# Altium schematic sheet FLASH.SchDoc(FLASH)
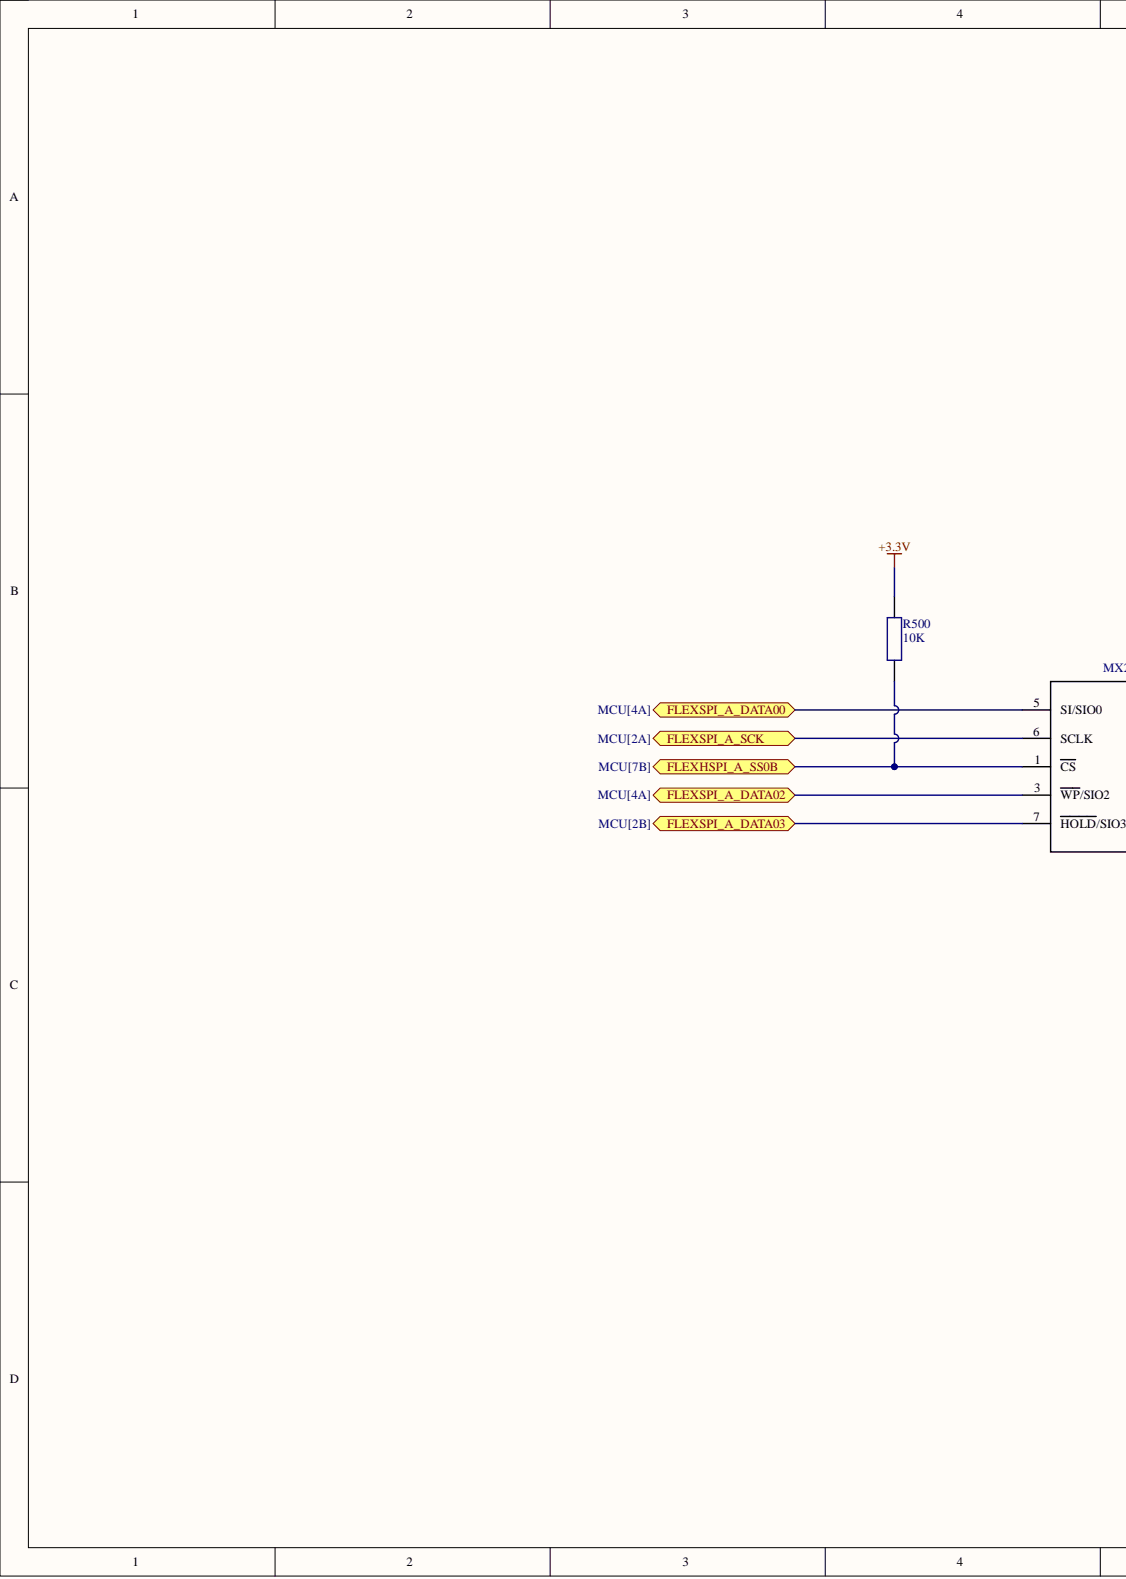
[diagram p0: FLASH.SchDoc, left]
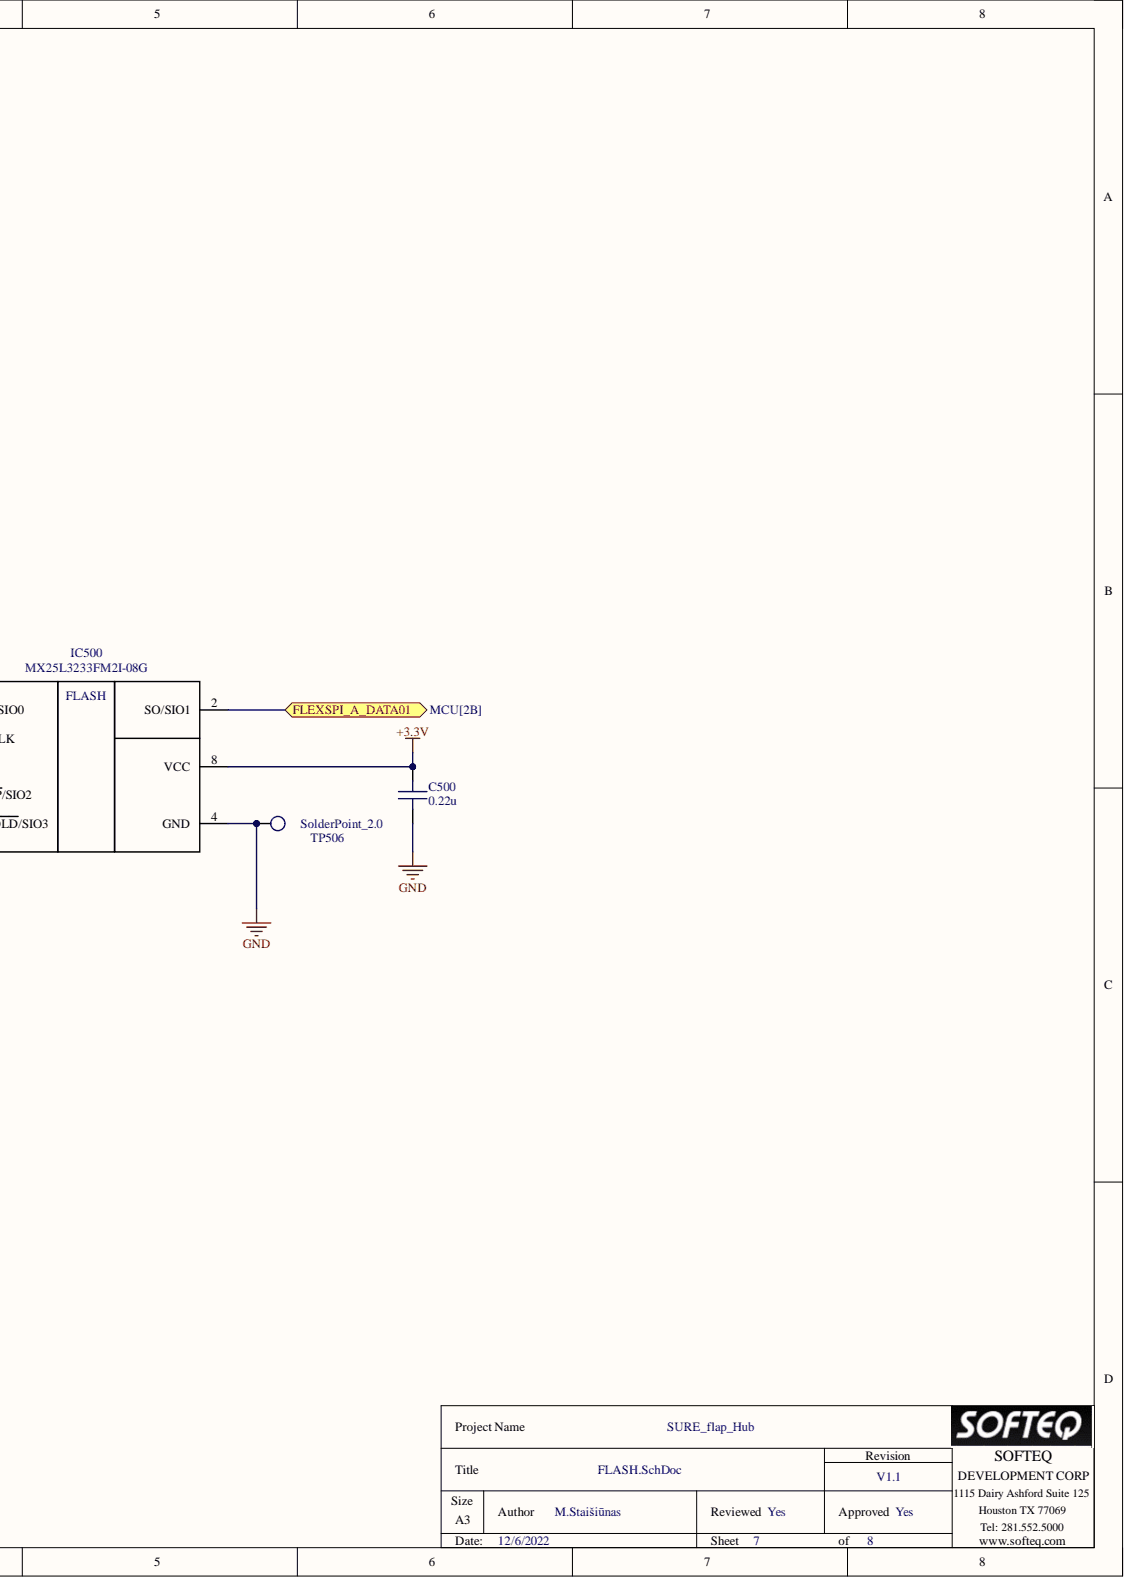
[diagram p1: FLASH.SchDoc, right]

## Parts
C500  value 0.22u  [C_0603]  (hidden comment: CC0603KRX7R9BB224)
IC500  MX25L3233FM2I-08G  [SOIC-8[4-4]_0.65_1.27_5.25X5.3X2.15]
R500  value 10K  [R_0402]  (hidden comment: RC0402FR-0710KL)
TP506  SolderPoint_2.0  [SSP_2.0]  (no BOM)

## Ports
FLEXHSPI_A_SS0B, FLEXSPI_A_DATA00, FLEXSPI_A_DATA01, FLEXSPI_A_DATA02, FLEXSPI_A_DATA03, FLEXSPI_A_SCK

## Nets
+3.3V: C500.2 IC500.8(VCC) R500.2
GND: C500.1 IC500.4(GND) TP506.1
FLEXHSPI_A_SS0B: IC500.1(CS) R500.1 ; port FLEXHSPI_A_SS0B
FLEXSPI_A_DATA00: IC500.5(SI/SIO0) ; port FLEXSPI_A_DATA00
FLEXSPI_A_DATA01: IC500.2(SO/SIO1) ; port FLEXSPI_A_DATA01
FLEXSPI_A_DATA02: IC500.3(WP/SIO2) ; port FLEXSPI_A_DATA02
FLEXSPI_A_DATA03: IC500.7(HOLD/SIO3) ; port FLEXSPI_A_DATA03
FLEXSPI_A_SCK: IC500.6(SCLK) ; port FLEXSPI_A_SCK

## Library data of the parts
C500 = CC0603KRX7R9BB224: Capacitor, 0.22uF, 10%, 50V, X7R, -55/+125, 0603; Manufacturer: Yageo; Database Table Name: Capacitors; Component Kind: Standard; ComponentHeight: 0.8mm; Library Name: Library.dblib; Mounted: Yes; PartNumber: CC0603KRX7R9BB224; SMD: Yes; Author: ChAl
IC500 = MX25L3233FM2I-08G: IC,  NOR Flash, QSPI, 32Mb, 133MHz, 2.65-3.6V, -40/+85, SOP-8; Manufacturer: Macronix; Database Table Name: IC Digital; Component Kind: Standard; ComponentHeight: 2.15mm; Library Name: Library.dblib; Mounted: Yes; PartNumber: MX25L3233FM2I-08G; SMD: Yes; Author: DZ
R500 = RC0402FR-0710KL: Resistor, 10K, 1%, 0.063W, -55/+155, 0402; Manufacturer: Yageo; Database Table Name: Resistors; Component Kind: Standard; ComponentHeight: 0.4mm; Library Name: Library.dblib; Mounted: Yes; PartNumber: RC0402FR-0710KL; SMD: Yes; Author: KAI
TP506 = SolderPoint_SMD_2.0: Solder Point, 2.0mm, SMD; Database Table Name: Connectors; ComponentHeight: 0mm; Library Name: Library.dblib; Mounted: Yes; PartNumber: SolderPoint_SMD_2.0; SMD: Yes; Author: KAI
